# Altium schematic sheet Power Supply.SchDoc
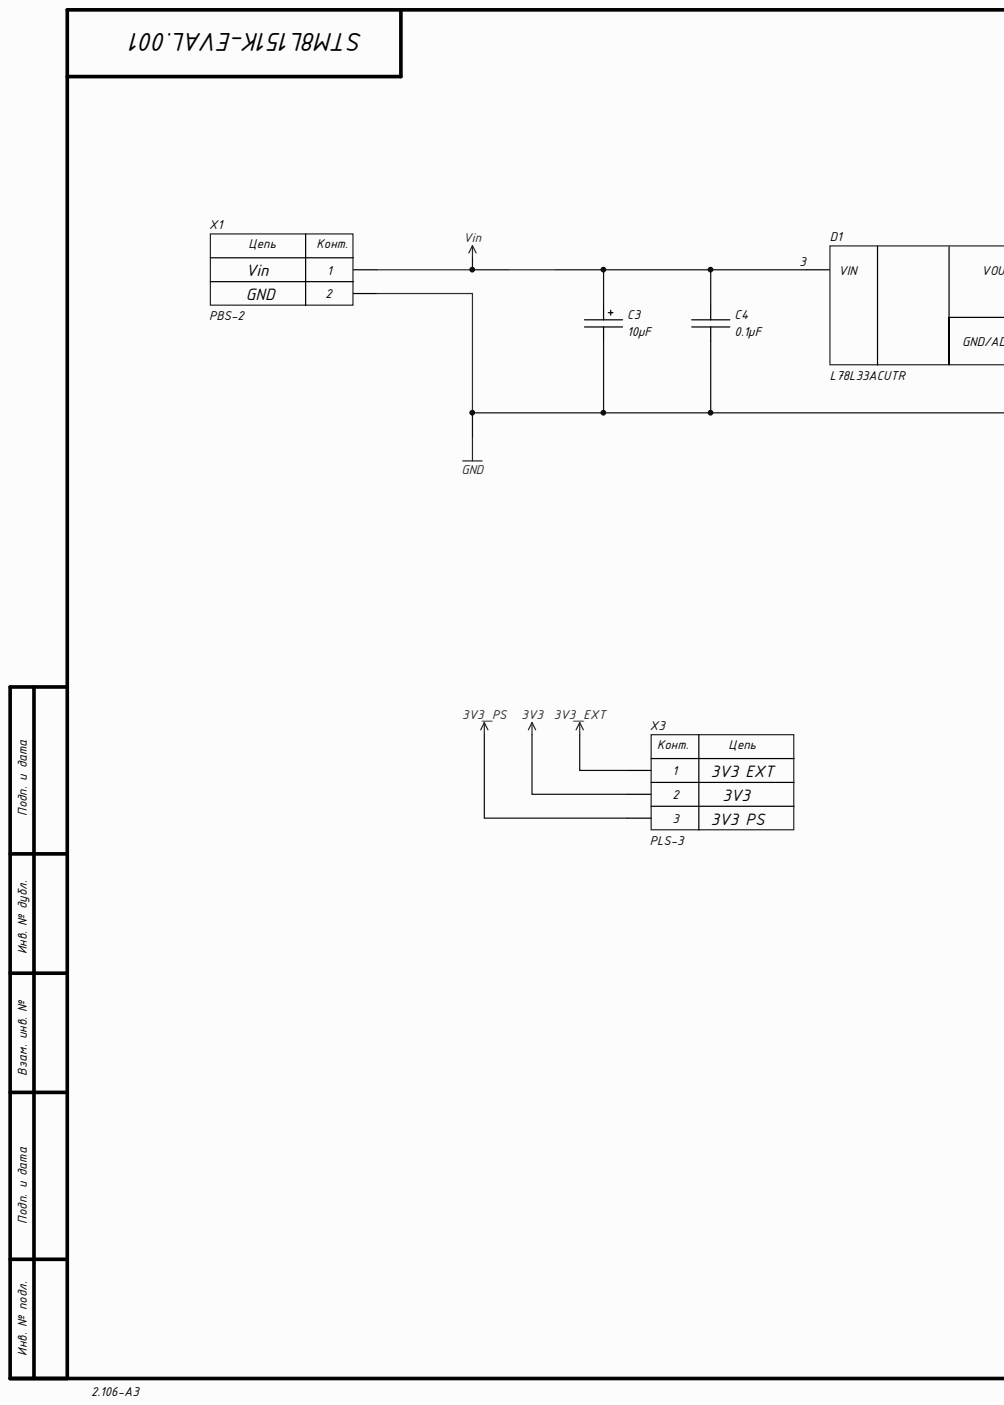
[diagram p0: Power Supply.SchDoc, left]
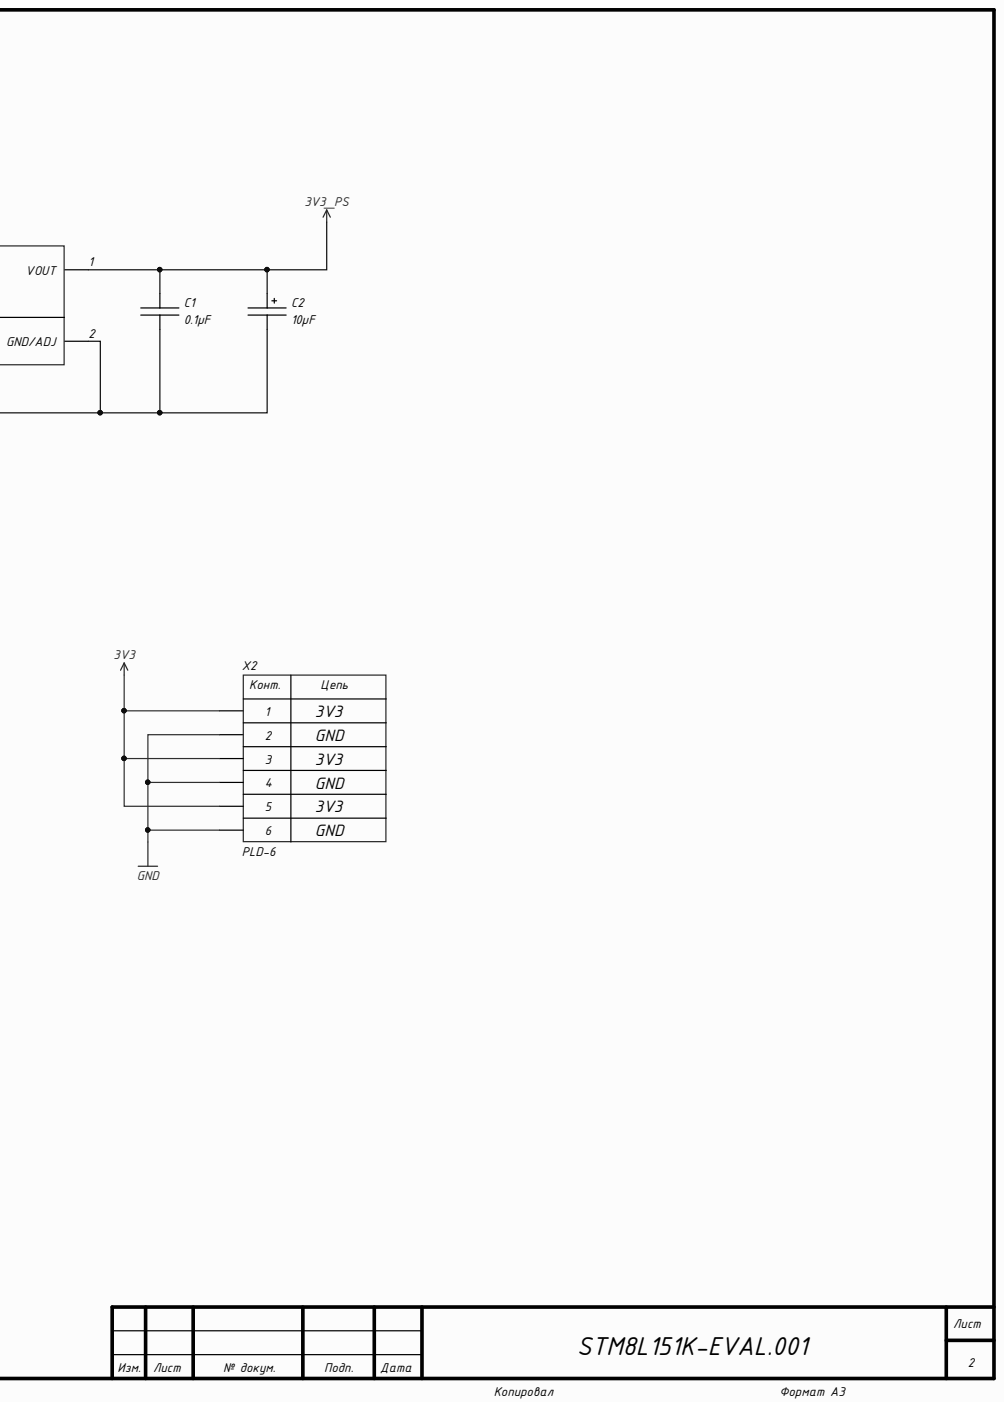
[diagram p1: Power Supply.SchDoc, right]

## Parts
C1  0.1µF  [CH0603C]
C2  10µF  [TANTAL_A]
C3  10µF  [TANTAL_A]
C4  0.1µF  [CH0603C]
D1  L78L33ACUTR  [SOT89_123]
X1  PBS-2  [CON: PBS-2]
X2  PLD-6  [CON: PLD-6]
X3  PLS-3  [CON: PLS-3]

## Nets
3V3: X2.1 X2.3 X2.5 X3.2
3V3_EXT: X3.1
3V3_PS: C1.1 C2.1 D1.1 X3.3
GND: C1.2 C2.2 C3.2 C4.2 D1.2 X1.2 X2.2 X2.4 X2.6
Vin: C3.1 C4.1 D1.3 X1.1

## Library data of the parts
C1 C4 = Capacitor: Component Kind: Standard; Library Name: Discrete.IntLib; Value: 0.1µF
C2 C3 = TantalCap: Component Kind: Standard; Library Name: Discrete.IntLib; Value: 10µF
D1 = L78LxxACUTR: Step-down; Manufacturer: ST Microelectronics; Component Kind: Standard; ComponentKind: SOT-89, 100mA; HelpURL: https://www.st.com/resource/en/datasheet/l78l.pdf; Library Name: Power Supplies.IntLib; Model: L78L33ACUTR
X1 = 2-Connector: Component Kind: Standard; Library Name: Connectors.IntLib; Model: PBS-2
X2 = 6-Connector: Component Kind: Standard; Library Name: Connectors.IntLib; Model: PLD-6
X3 = 3-Connector: Component Kind: Standard; Library Name: Connectors.IntLib; Model: PLS-3
